# Altium schematic sheet Speaker.SchDoc
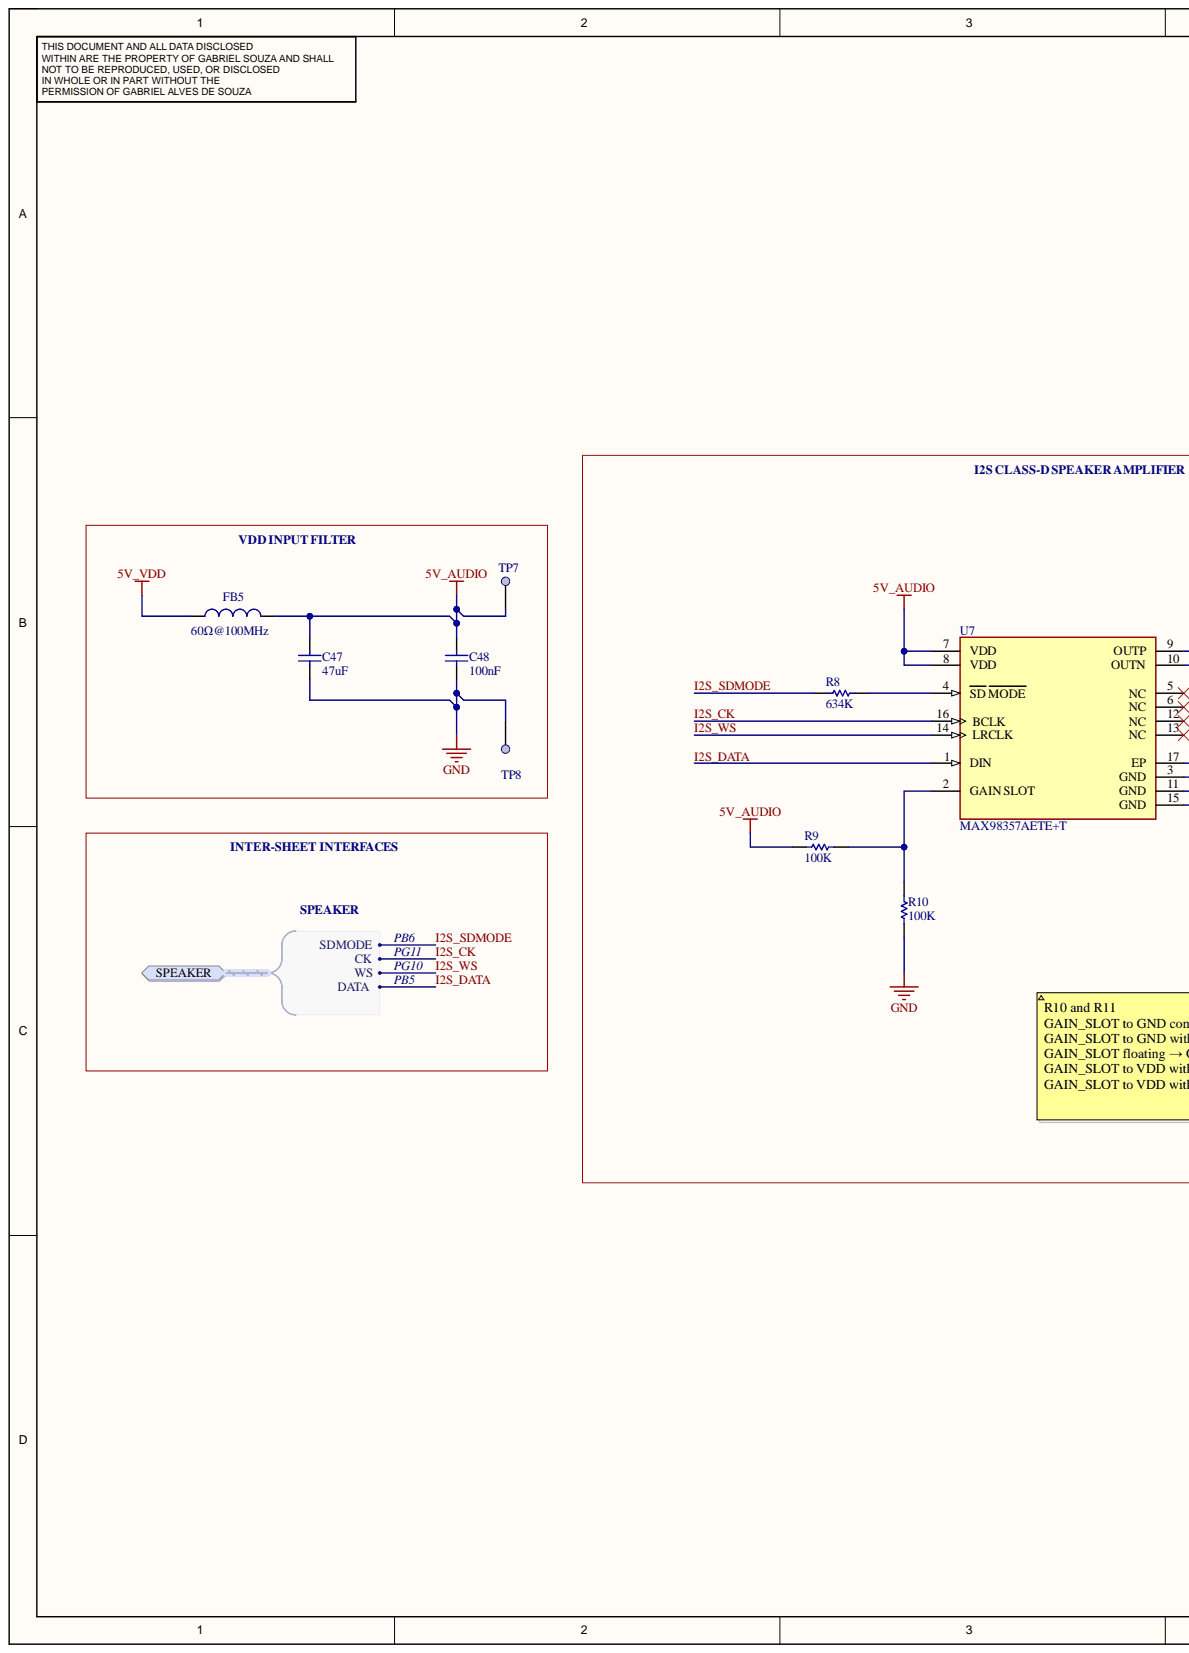
[diagram p0: Speaker.SchDoc, left]
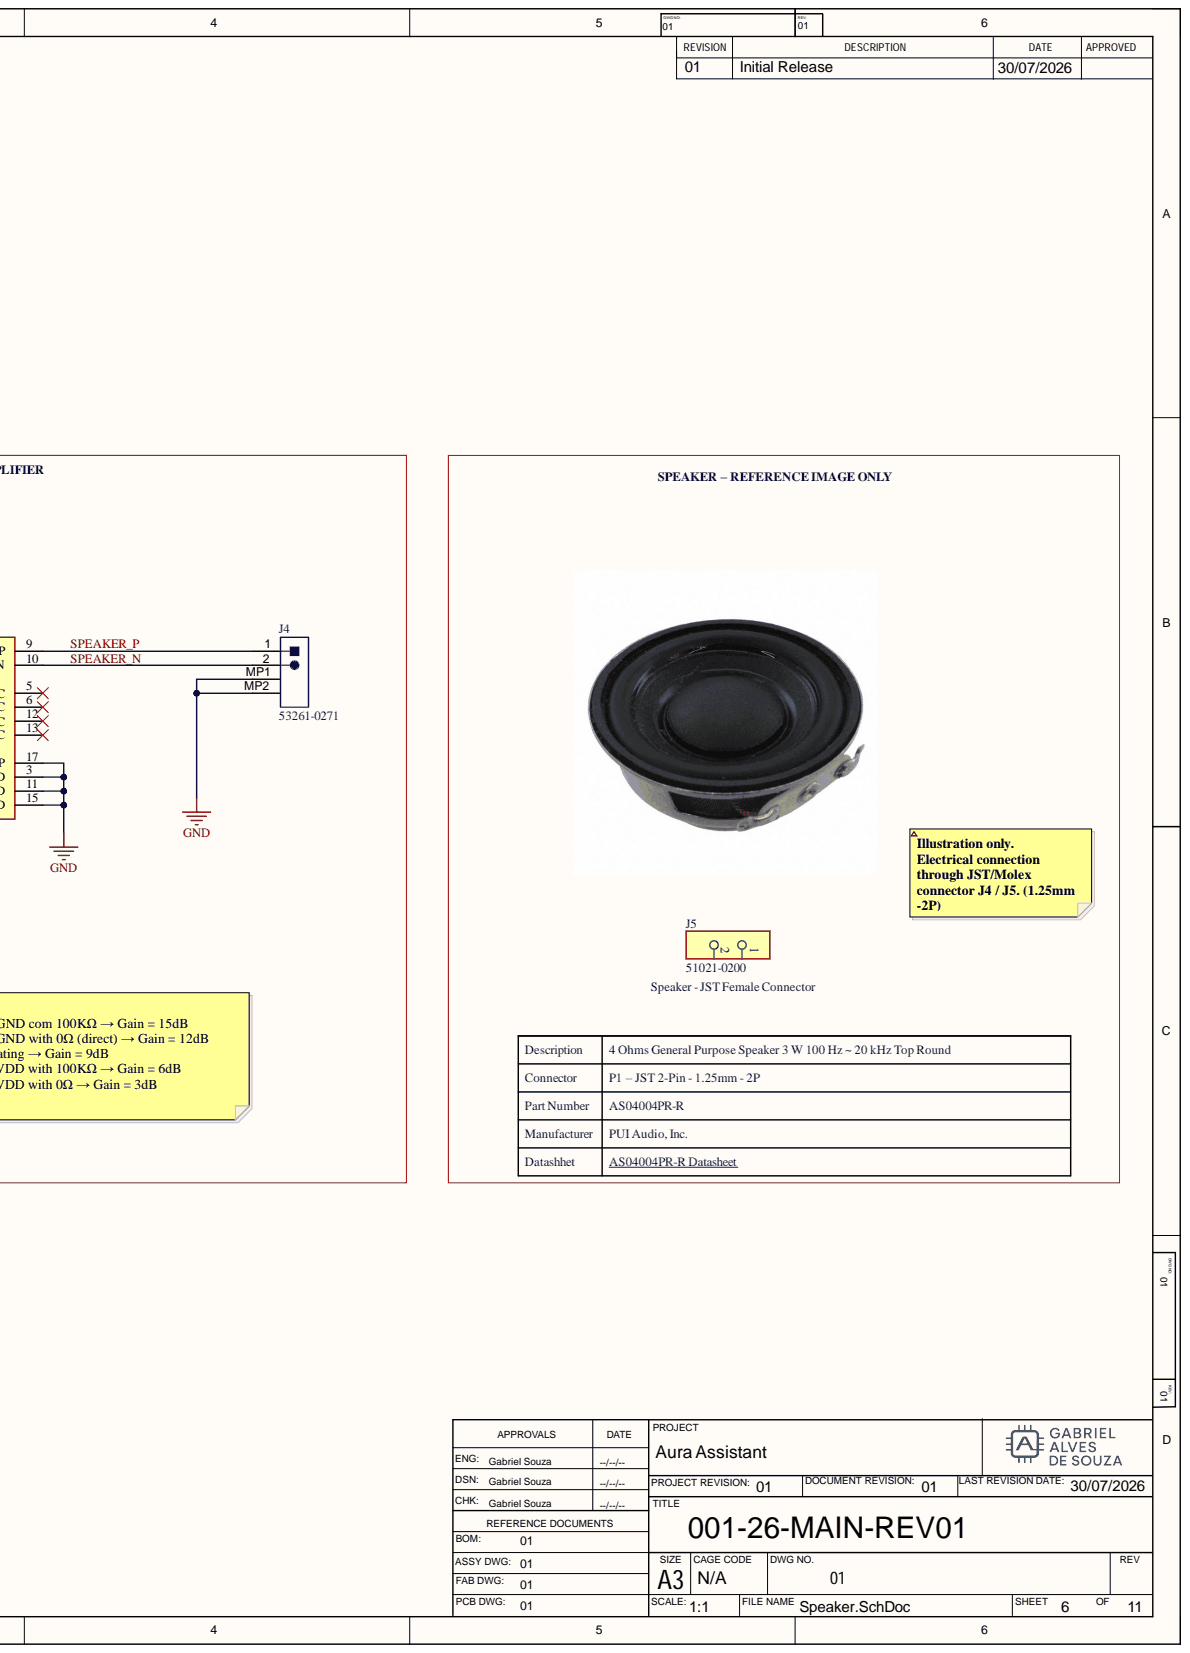
[diagram p1: Speaker.SchDoc, right]

## Parts
C47  value 47uF  [C_1206_IPC_N]  (hidden comment: Capacitor)
C48  value 100nF  [C_0402_IPC_N]  (hidden comment: Capacitor)
FB5  value 60Ω@100MHz  [L_0805_IPC_N]  (hidden comment: Inductor)
J4  53261-0271  [MOLX-53261-0271_V]
J5  51021-0200  Conn Gender: Female  [FP-51021-0200-MFG]  (no BOM)
R8  value 634K  [R_0603_IPC_N]  (hidden comment: Resistor)
R9  value 100K  [R_0603_IPC_N]  (hidden comment: Resistor)
R10  value 100K  [R_0603_IPC_N]  (hidden comment: Resistor)
TP7  Test Point  [TP_SMD_2MM]  (value not drawn)  (no BOM)
TP8  Test Point  [TP_SMD_2MM]  (value not drawn)  (no BOM)
U7  MAX98357AETE+T  [FP-T1633-4-MFG]

## Ports
SPEAKER, SPEAKER.CK, SPEAKER.DATA, SPEAKER.SDMODE, SPEAKER.WS

## Nets
5V_AUDIO: C47.2 C48.2 FB5.2 R9.2 TP7.1 U7.7(VDD) U7.8(VDD)
5V_VDD: FB5.1
GND: C47.1 C48.1 J4.MP1 J4.MP2 R10.2 TP8.1 U7.3(GND) U7.11(GND) U7.15(GND) U7.17(EP)
I2S_CK: U7.16(BCLK) ; port SPEAKER
I2S_DATA: U7.1(DIN) ; port SPEAKER
I2S_SDMODE: R8.2 ; port SPEAKER
I2S_WS: U7.14(LRCLK) ; port SPEAKER
SPEAKER_N: J4.2 U7.10(OUTN)
SPEAKER_P: J4.1 U7.9(OUTP)
NetR8_1: R8.1 U7.4(SD_MODE)
NetR9_1: R9.1 R10.1 U7.2(GAIN_SLOT)

Not connected: U7 5(NC) 6(NC) 12(NC) 13(NC)

## Library data of the parts
C47 = CAP: Capacitor, MLCC, 47uF, 16V, X5R, +/-20%, 1206, T=0,5mm; Manufacturer: Chinocera; Manufacturer_Part_Number: HGC1206R5476M160NSPJ; Component Kind: Standard; ComponentLink1Description: Datasheet; ComponentLink1URL: https://www.lcsc.com/datasheet/C338032.pdf?spm=wm.sxq.inf.ggs___wm.fly.bg.6.xh&lcsc_vid=TlIKVlcFRVJWVl1WQVFfBlQDRFgPAVVQRFhaBQIFFgQxVlNeRVRbU1RQQ1dWUTtW; Order_Code_LCSC: C7472975; Package: 1206; Library Name: Gabriel_Lib.IntLib
C48 = CAP: Capacitor, MLCC, 100nF, 16V, X7R, +/-10%, 0402, T=0,5mm; Manufacturer: TDK; Manufacturer_Part_Number: C1005X7R1C104KT000F; Component Kind: Standard; ComponentLink1Description: Datasheet; ComponentLink1URL: https://www.lcsc.com/datasheet/C338032.pdf?spm=wm.sxq.inf.ggs___wm.fly.bg.6.xh&lcsc_vid=TlIKVlcFRVJWVl1WQVFfBlQDRFgPAVVQRFhaBQIFFgQxVlNeRVRbU1RQQ1dWUTtW; Order_Code_LCSC: C338032; Package: 0402; Library Name: Gabriel_Lib.IntLib
FB5 = Inductor: FERRITE BEAD 60Ω 0805 1LN; Manufacturer: muRata; Manufacturer_Part_Number: BLM21PG600SN1D; Component Kind: Standard; ComponentLink1URL: http://tunmcdsvn.tun.st.com/Component_Documentation_Library/Trunk/Inductor/MURATA_DFE201612P_Series/; Order_Code_LCSC: C18305; Part_Type: Inductor; Library Name: Gabriel_Lib.IntLib
J4 = CMP-2000-05633-1: PicoBlade(TM) Header, Right Angle, -40 to 85 degC, 2-Pin SMD, RoHS, Tape and Reel; Brand: Molex; Current - Max: 1A; Number of Positions: 2; Package Version: Rev. H, 11/2014; Pitch: 1.25mm; Voltage - Max: 125V; Component Kind: Standard; Contact Material: PhosphorBronze; Contact Plating: Tin; Current Rating: 1A; Datasheet URL: http://www.molex.com/webdocs/datasheets/pdf/en-us/0532610271_PCB_HEADERS.pdf; Datasheet Version: 03/2016; Factory Pack Quantity: 1000; Housing Material: Thermoplastic; Library Name: Altium Content Vault; Manufacturer: Molex; Manufacturer URL: http://www.molex.com/; Mounting Angle: Right; Mounting Style: SMD/SMT; Mounting Technology: SurfaceMount; Number of Positions / Contacts: 2; Number of Rows: 1; Order_Code_LCSC: C177225; Package Description: 2-Leads Header, Pitch 1.25 mm, Mated Height 3.4 mm; Package Reference: 53261-0271; Package URL: http://www.molex.com/pdm_docs/sd/532610271_sd.pdf; Packaging: Reel; Product Category: 1.25mmConnectors; Product Type: Headers; RoHS: Details; Series: 53261; Termination Style: SMD; Tradename: PicoBlade; Type: Shrouded; Voltage Rating: 125V
J5 = CMP-04776-000072-1: CONN HOUSING 2POS 1.25MM NATURAL; Manufacturer: Molex; Manufacturer Part Number: C293613; Conn Orientation: Straight; Is Female: yes; Number Of Contacts: 2; Automotive: No; Category: Conn; ComponentLink2Description: Datasheet; ComponentLink2URL: https://www.molex.com/webdocs/datasheets/pdf/en-us/0510210200_CRIMP_HOUSINGS.pdf; Device Class L1: Connectors; Device Class L2: Headers and Wire Housings; Device Class L3: unset; Digikey Description: CONN HOUSING 2POS 1.25MM NATURAL; Footprint Url: https://www.molex.com/pdm_docs/sd/510210200_sd.pdf; Height: 4.15mm; Lead Free: Yes; Library Name: Altium Content Vault; Mouser Description: Headers & Wire Housings RECEPTACLE 2P; Number Of Rows: 1; Octopart: https://octopart.com/51021-0200-molex-34792; Package: 0; Pitch: 1.25mm; RoHS: TRUE; Temperature: -40°C to +105°C; Temperature Range High: +105°C; Temperature Range Low: -40°C
R8 = RES: Resistor, 634K, 0603, 0.1%, 100mW; Manufacturer: FOJAN; Manufacturer_Part_Number: FRC0603F6343TS; Component Kind: Standard; ComponentLink1Description: Datasheet; Order_Code_LCSC: C2999499; Package: 0603; Part_Type: Resistor; Library Name: Gabriel_Lib.IntLib
R9 R10 = RES: Resistor, 100K, 0603, 0.1%, 100mW; Manufacturer: YAGEO; Manufacturer_Part_Number: RT0603BRD07100KL; Component Kind: Standard; ComponentLink1Description: Datasheet; Order_Code_LCSC: C122538; Package: 0603; Part_Type: Resistor; Library Name: Gabriel_Lib.IntLib
TP7 TP8 = MCD-00177: Test Point, SMD, 2mm; Part_Preference: Recommended; Part_Type: Misc; Component_Country_Of_Origin: Unknown; Database Table Name: MCD_PARTS$; ID: 2690; ShowFields: YES; ComponentLink1URL: http://tunmcdsvn.tun.st.com/Component_Documentation_Library/Trunk/Misc; Internal_Part_Number: MCD-00177; Library Name: MCD_PARTS_database.DbLib; Manufacturer: N/A; Manufacturer_Part_Number: N/A; Order_Code_Digikey: N/A; Order_Code_Farnell: N/A; Order_Code_RS: N/A; Status: Released; Value: N/A
U7 = CMP-05698-000018-1: IC AMP CLASS D MONO 3.2W 16TQFN; Max Output Current: 1.6A; Max Power Dissipation: 1.666W; Max Supply Voltage: 5.5V; Min Supply Voltage: 2.5V; Power Supply Rejection Ratio (PSRR): 77dB; Voltage Gain: 15dB; Component Kind: Standard; Contact Plating: Tin; Library Name: Altium Content Vault; Max Operating Temperature: 85°C; Max Output Power: 3.2W; Min Operating Temperature: -40°C; Mount: Surface Mount; Number of Pins: 16; Order_Code_LCSC: C910544; Packaging: Tape and Reel; Radiation Hardening: No; RoHS Compliant: Yes; Series: -
